# Altium schematic sheet STB_ValidationBoard.SchDoc
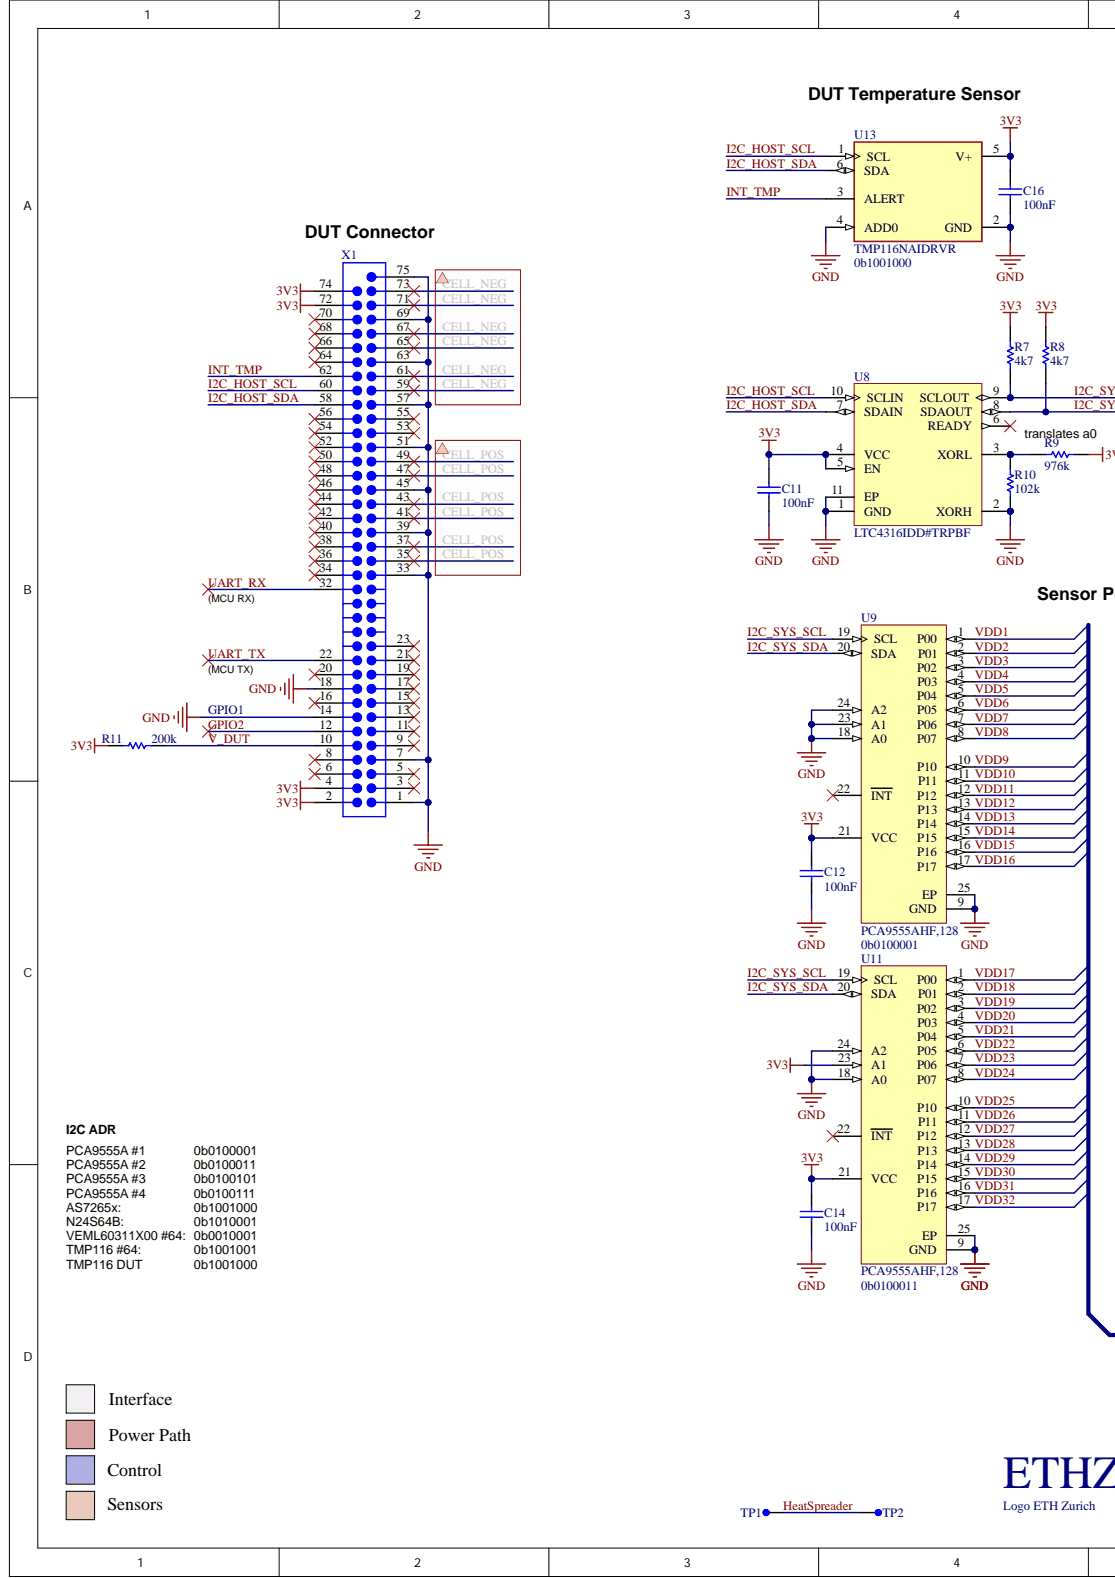
[diagram p0: STB_ValidationBoard.SchDoc, left]
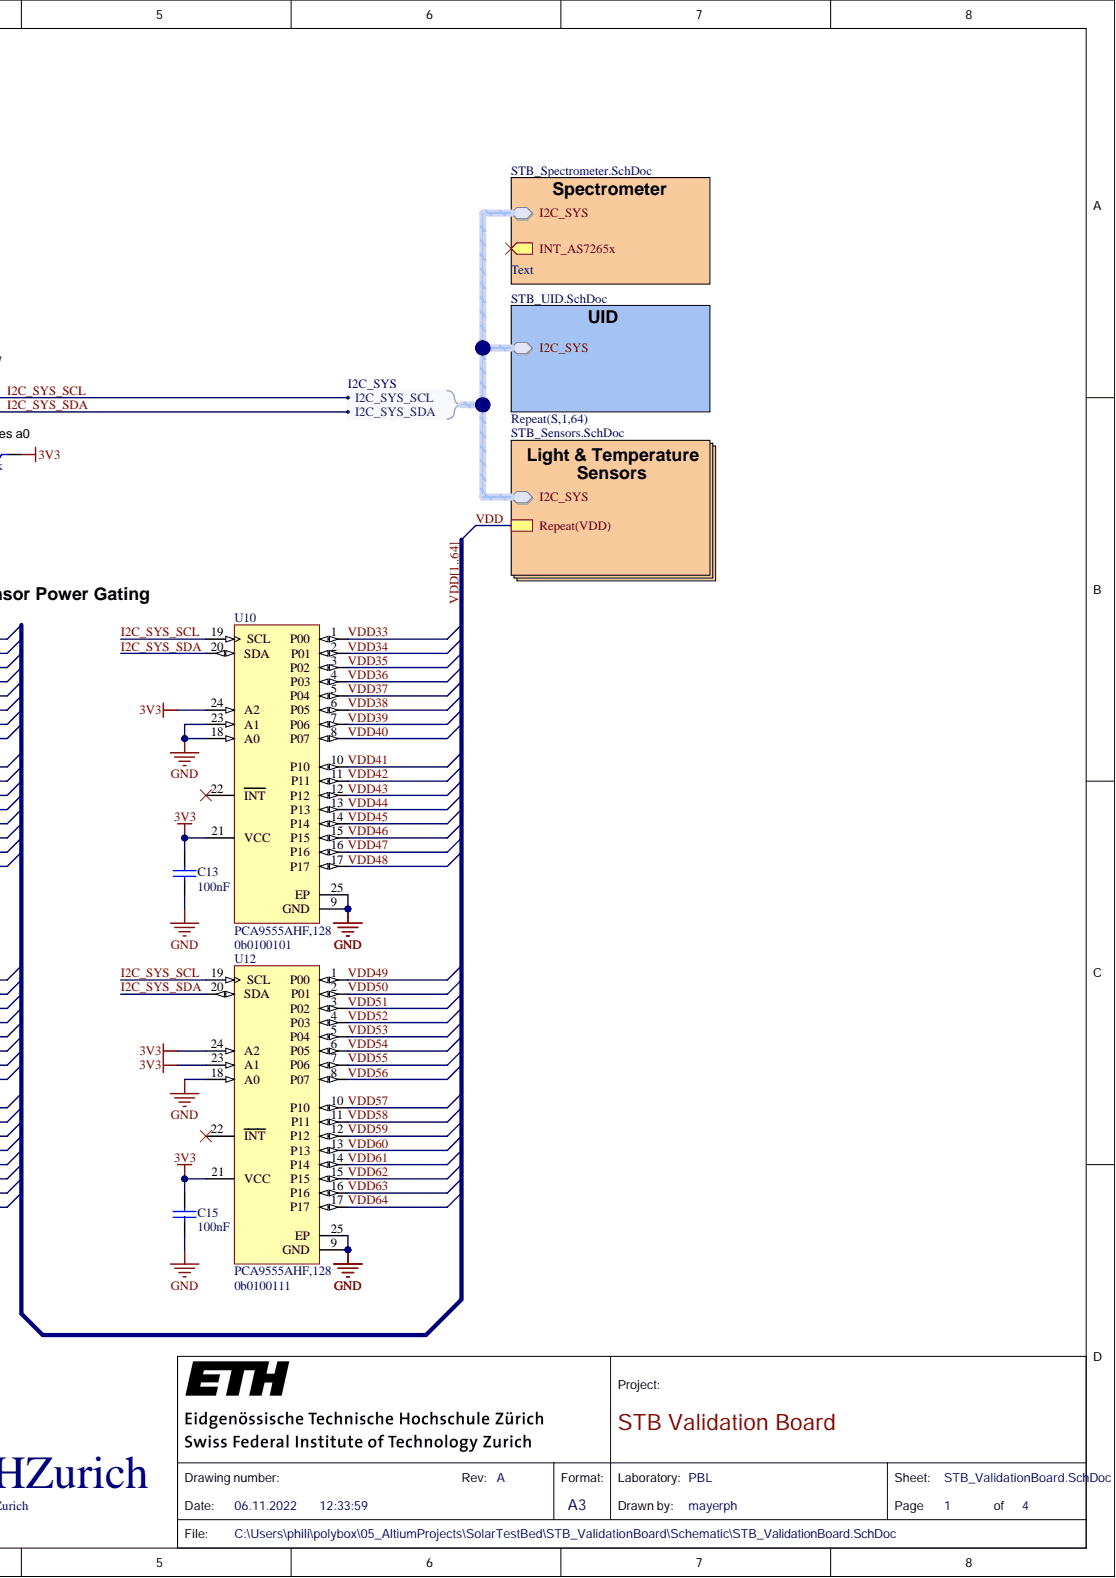
[diagram p1: STB_ValidationBoard.SchDoc, right]

## Parts
A1  Logo ETH Zurich  [eth-zurich_5mm]  (no BOM)
C11  100nF  [CAPC1050X55X23LL05T13]
C12  100nF  [CAPC1050X55X23LL05T13]
C13  100nF  [CAPC1050X55X23LL05T13]
C14  100nF  [CAPC1050X55X23LL05T13]
C15  100nF  [CAPC1050X55X23LL05T13]
C16  100nF  [CAPC1050X55X23LL05T13]
R7  4k7  [RESC1005X40X25LL05T10]
R8  4k7  [RESC1005X40X25LL05T10]
R9  976k  [RESC1005X40X25LL05T05]
R10  102k  [RESC1005X40X25LL05T05]
R11  200k  [RESC1005X40X25LL05T10]
TP1  testPoint_1mm  [TP-SM-C-100]  (value not drawn)  (no BOM)
TP2  testPoint_1mm  [TP-SM-C-100]  (value not drawn)  (no BOM)
U8  LTC4316IDD#TRPBF  [DFN10(3x3)_N]
U9  PCA9555AHF,128  [RGE0024C]
U10  PCA9555AHF,128  [RGE0024C]
U11  PCA9555AHF,128  [RGE0024C]
U12  PCA9555AHF,128  [RGE0024C]
U13  TMP116NAIDRVR  Address: 0b1001000  [FP-DRV0006B-MFG]
X1  CONN PWR CARD EDGE PCB SLOT MALE  [CON-67_0.5MM_PCB_SLOT]  (value not drawn)  (no BOM)

## Nets
3V3: C11.2 C12.2 C13.2 C14.2 C15.2 C16.2 R7.2 R8.2 R9.1 R11.2 U8.4(VCC) U8.5(EN) U9.21(VCC) U10.21(VCC) U10.24(A2) U11.21(VCC) U11.23(A1) U12.21(VCC) U12.23(A1) U12.24(A2) U13.5(V+) X1.2 X1.4 X1.72 X1.74
GND: C11.1 C12.1 C13.1 C14.1 C15.1 C16.1 R10.2 U8.1(GND) U8.2(XORH) U8.11(EP) U9.9(GND) U9.18(A0) U9.23(A1) U9.24(A2) U9.25(EP) U10.9(GND) U10.18(A0) U10.23(A1) U10.25(EP) U11.9(GND) U11.18(A0) U11.24(A2) U11.25(EP) U12.9(GND) U12.18(A0) U12.25(EP) U13.2(GND) U13.4(ADD0) X1.1 X1.7 X1.14 X1.18 X1.33 X1.39 X1.45 X1.51 X1.57 X1.63 X1.69 X1.75
GPIO2: X1.12
HeatSpreader: TP1.1 TP2.1
I2C_HOST_SCL: U8.10(SCLIN) U13.1(SCL) X1.60
I2C_HOST_SDA: U8.7(SDAIN) U13.6(SDA) X1.58
I2C_SYS_SCL: R7.1 U8.9(SCLOUT) U9.19(SCL) U10.19(SCL) U11.19(SCL) U12.19(SCL)
I2C_SYS_SDA: R8.1 U8.8(SDAOUT) U9.20(SDA) U10.20(SDA) U11.20(SDA) U12.20(SDA)
INT_TMP: U13.3(ALERT) X1.62
UART_RX: X1.32
UART_TX: X1.22
V_DUT: R11.1 X1.10
VDD1: U9.1(P00)  [also labelled VDD]
VDD2: U9.2(P01)  [also labelled VDD]
VDD3: U9.3(P02)  [also labelled VDD]
VDD4: U9.4(P03)  [also labelled VDD]
VDD5: U9.5(P04)  [also labelled VDD]
VDD6: U9.6(P05)  [also labelled VDD]
VDD7: U9.7(P06)  [also labelled VDD]
VDD8: U9.8(P07)  [also labelled VDD]
VDD9: U9.10(P10)  [also labelled VDD]
VDD10: U9.11(P11)  [also labelled VDD]
VDD11: U9.12(P12)  [also labelled VDD]
VDD12: U9.13(P13)  [also labelled VDD]
VDD13: U9.14(P14)  [also labelled VDD]
VDD14: U9.15(P15)  [also labelled VDD]
VDD15: U9.16(P16)  [also labelled VDD]
VDD16: U9.17(P17)  [also labelled VDD]
VDD17: U11.1(P00)  [also labelled VDD]
VDD18: U11.2(P01)  [also labelled VDD]
VDD19: U11.3(P02)  [also labelled VDD]
VDD20: U11.4(P03)  [also labelled VDD]
VDD21: U11.5(P04)  [also labelled VDD]
VDD22: U11.6(P05)  [also labelled VDD]
VDD23: U11.7(P06)  [also labelled VDD]
VDD24: U11.8(P07)  [also labelled VDD]
VDD25: U11.10(P10)  [also labelled VDD]
VDD26: U11.11(P11)  [also labelled VDD]
VDD27: U11.12(P12)  [also labelled VDD]
VDD28: U11.13(P13)  [also labelled VDD]
VDD29: U11.14(P14)  [also labelled VDD]
VDD30: U11.15(P15)  [also labelled VDD]
VDD31: U11.16(P16)  [also labelled VDD]
VDD32: U11.17(P17)  [also labelled VDD]
VDD33: U10.1(P00)  [also labelled VDD]
VDD34: U10.2(P01)  [also labelled VDD]
VDD35: U10.3(P02)  [also labelled VDD]
VDD36: U10.4(P03)  [also labelled VDD]
VDD37: U10.5(P04)  [also labelled VDD]
VDD38: U10.6(P05)  [also labelled VDD]
VDD39: U10.7(P06)  [also labelled VDD]
VDD40: U10.8(P07)  [also labelled VDD]
VDD41: U10.10(P10)  [also labelled VDD]
VDD42: U10.11(P11)  [also labelled VDD]
VDD43: U10.12(P12)  [also labelled VDD]
VDD44: U10.13(P13)  [also labelled VDD]
VDD45: U10.14(P14)  [also labelled VDD]
VDD46: U10.15(P15)  [also labelled VDD]
VDD47: U10.16(P16)  [also labelled VDD]
VDD48: U10.17(P17)  [also labelled VDD]
VDD49: U12.1(P00)  [also labelled VDD]
VDD50: U12.2(P01)  [also labelled VDD]
VDD51: U12.3(P02)  [also labelled VDD]
VDD52: U12.4(P03)  [also labelled VDD]
VDD53: U12.5(P04)  [also labelled VDD]
VDD54: U12.6(P05)  [also labelled VDD]
VDD55: U12.7(P06)  [also labelled VDD]
VDD56: U12.8(P07)  [also labelled VDD]
VDD57: U12.10(P10)  [also labelled VDD]
VDD58: U12.11(P11)  [also labelled VDD]
VDD59: U12.12(P12)  [also labelled VDD]
VDD60: U12.13(P13)  [also labelled VDD]
VDD61: U12.14(P14)  [also labelled VDD]
VDD62: U12.15(P15)  [also labelled VDD]
VDD63: U12.16(P16)  [also labelled VDD]
VDD64: U12.17(P17)  [also labelled VDD]
NetR9_2: R9.2 R10.1 U8.3(XORL)
NetU8_6: U8.6(READY)
NetU9_22: U9.22(INT)
NetU10_22: U10.22(INT)
NetU11_22: U11.22(INT)
NetU12_22: U12.22(INT)
NetX1_3: X1.3
NetX1_5: X1.5
NetX1_6: X1.6
NetX1_8: X1.8
NetX1_9: X1.9
NetX1_11: X1.11
NetX1_13: X1.13
NetX1_15: X1.15
NetX1_16: X1.16
NetX1_17: X1.17
NetX1_19: X1.19
NetX1_20: X1.20
NetX1_21: X1.21
NetX1_23: X1.23
NetX1_34: X1.34
NetX1_35: X1.35
NetX1_36: X1.36
NetX1_37: X1.37
NetX1_38: X1.38
NetX1_40: X1.40
NetX1_41: X1.41
NetX1_42: X1.42
NetX1_43: X1.43
NetX1_44: X1.44
NetX1_46: X1.46
NetX1_47: X1.47
NetX1_48: X1.48
NetX1_49: X1.49
NetX1_50: X1.50
NetX1_52: X1.52
NetX1_53: X1.53
NetX1_54: X1.54
NetX1_55: X1.55
NetX1_56: X1.56
NetX1_59: X1.59
NetX1_61: X1.61
NetX1_64: X1.64
NetX1_65: X1.65
NetX1_66: X1.66
NetX1_67: X1.67
NetX1_68: X1.68
NetX1_70: X1.70
NetX1_71: X1.71
NetX1_73: X1.73
INT_AS7265x:

## Library data of the parts
A1 = CMP-00038-1: Library Name: ETHZ Vault
C11-C16 = CMP-2000-05439-1: Chip Capacitor, 100 nF, +/- 10%, 16 V, -55 to 125 degC, 0402 (1005 Metric), RoHS, Tape and Reel; Manufacturer: Samsung Electro-Mechanics; Manufacturer Part Number: CL05B104KO5NNNC; Capacitor Type: Ceramic; Dielectric: X7R; Multilayer: Yes; Rated DC Voltage (URdc): 16V; Case Size/Dimension: 0402; Category: Ceramic Capacitors; Component Kind: Standard; ComponentLink1Description: https://datasheet.ciiva.com:443/pdfs/VipMasterIC/IC/SEMS/SEMS-S-A0008384515/SEMS-S-A0008872921-1.pdf?src-supplier=IHS+Markit; ComponentLink1URL: https://datasheet.ciiva.com:443/pdfs/VipMasterIC/IC/SEMS/SEMS-S-A0008384515/SEMS-S-A0008872921-1.pdf?src-supplier=IHS+Markit; ComponentLink2Description: https://datasheet.ciiva.com/26920/getdatasheetpartid-359683-26920169.pdf?src-supplier=Verical; ComponentLink2URL: https://datasheet.ciiva.com/26920/getdatasheetpartid-359683-26920169.pdf?src-supplier=Verical; ComponentLink3Description: https://datasheet.ciiva.com/29198/458718-da-01-en-vs-kondensator-0402-29198423.pdf?src-supplier=Conrad; ComponentLink3URL: https://datasheet.ciiva.com/29198/458718-da-01-en-vs-kondensator-0402-29198423.pdf?src-supplier=Conrad; ComponentLink4Description: https://datasheet.ciiva.com/26309/mlcc-catalogdownload2013-eng-26309003.pdf?src-supplier=Distrelec; ComponentLink4URL: https://datasheet.ciiva.com/26309/mlcc-catalogdownload2013-eng-26309003.pdf?src-supplier=Distrelec; ComponentLink5Description: https://datasheet.ciiva.com/16208/cl05b104kq5nnnc-16208343.pdf?src-supplier=Future+Electronics; ComponentLink5URL: https://datasheet.ciiva.com/16208/cl05b104kq5nnnc-16208343.pdf?src-supplier=Future+Electronics; Datasheet URL: https://datasheet.ciiva.com/29198/458718-da-01-en-vs-kondensator-0402-29198423.pdf; Datasheet Version: Nov-10; Dielectric Material: Ceramic; Height: 0.55mm; JESD-609 Code: e3; Length: 1mm; Library Name: Altium Content Vault; Manufacturer URL: http://www.samsungsem.com/global/index.jsp; Max Operating Temperature: 125°C; Min Operating Temperature: -55°C; Mount: Surface Mount; Negative Tolerance: 10%; Number of Pins: 2; Package Shape: Rectangular; Package Style: SMTMeter; Packaging: Tape and Reel; Positive Tolerance: 10%; Pricing 1: 1=0.10000, 10=0.02400, 50=0.01300, 100=0.01050, 500=0.00752, 1000=0.00592, 2500=0.00538, 5000=0.00495 (USD); Rated Voltage: 16V; REACH SVHC: Yes; RoHS: Yes; RoHS Compliant: Yes; Size Code: 0402; Stock 1: 24854634; Supplier 1: Digi-Key; Supplier Part Number 1: 1276-1001-6-ND; Temperature Characteristics Code: X7R; Terminal Finish: Nickel; Termination: Wraparound; Tolerance (%): ±10%; Value: 100nF; Width: 0.5mm
R7 R8 = CMP-1011-00586-2: Manufacturer: Yageo / Phycomp; Manufacturer Part Number: RC0402FR-074K7L; Additional Feature: LASER TRIMMABLE; Package Height: 0.35mm; Package Length: 1mm; Package Width: 0.5mm; Rated Power Dissipation (P): 62.5mW; Rated Temperature: 70°C; Temperature Coefficient: 100ppm/°C; Tolerance: 1%; Value: 4.7 kOhm; Working Voltage: 50V; Category: Chip SMD Resistors; Component Kind: Standard; ComponentLink1Description: https://datasheet.ciiva.com:443/pdfs/VipMasterIC/IC/YAGO/YAGO-S-A0008145938/YAGO-S-A0008145938-1.pdf?src-supplier=IHS+Markit; ComponentLink1URL: https://datasheet.ciiva.com:443/pdfs/VipMasterIC/IC/YAGO/YAGO-S-A0008145938/YAGO-S-A0008145938-1.pdf?src-supplier=IHS+Markit; ComponentLink2Description: https://datasheet.ciiva.com/22661/1686128-22661342.pdf?src-supplier=Element14; ComponentLink2URL: https://datasheet.ciiva.com/22661/1686128-22661342.pdf?src-supplier=Element14; ComponentLink3Description: https://datasheet.ciiva.com/7850/1018594-7850022.pdf?src-supplier=Element14; ComponentLink3URL: https://datasheet.ciiva.com/7850/1018594-7850022.pdf?src-supplier=Element14; ComponentLink4Description: https://datasheet.ciiva.com/7850/1018594-7850023.pdf?src-supplier=Element14; ComponentLink4URL: https://datasheet.ciiva.com/7850/1018594-7850023.pdf?src-supplier=Element14; ComponentLink5Description: https://datasheet.ciiva.com/15215/getdatasheetpartid-328119-15215035.pdf?src-supplier=Verical; ComponentLink5URL: https://datasheet.ciiva.com/15215/getdatasheetpartid-328119-15215035.pdf?src-supplier=Verical; Construction: Chip; JESD-609 Code: e3; Library Name: Altium Content Vault; Max Operating Temperature: 155°C; Min Operating Temperature: -55°C; Mount: Surface Mount; Number of Pins: 2; Package Shape: Rectangular; Package Style: SMTMeter; Packaging: Tape and Reel; Part Number: RC0402FR-074K7L; Pricing 1: 1=0.10000, 10=0.01500, 100=0.00600, 1000=0.00267, 2500=0.00232, 5000=0.00192 (USD); REACH SVHC: Yes; Resistor Type: FIXED RESISTOR; RoHS: Yes; RoHS Compliant: Yes; Size Code: 0402; Stock 1: 23638739; Supplier 1: Digi-Key; Supplier Part Number 1: 311-4.7KLRDKR-ND; Technology: METAL GLAZE/THICK FILM; Terminal Finish: Nickel; Termination: Wraparound
R9 = CMP-2002-01120-1: Manufacturer: Panasonic; Manufacturer Part Number: ERJ-2RKF9763X; Package Height: 0.35mm; Package Length: 1mm; Package Width: 0.5mm; Rated Power Dissipation (P): 100mW; Rated Temperature: 70°C; Reference Standard: AEC-Q200; Temperature Coefficient: 100ppm/°C; Tolerance: 1%; Value: 976 kOhm; Working Voltage: 50V; Category: Chip SMD Resistors; Component Kind: Standard; ComponentLink1Description: https://datasheet.ciiva.com:443/pdfs/VipMasterIC/IC/PANA/PANA-S-A0009889626/PANA-S-A0009889626-1.pdf?src-supplier=IHS+Markit; ComponentLink1URL: https://datasheet.ciiva.com:443/pdfs/VipMasterIC/IC/PANA/PANA-S-A0009889626/PANA-S-A0009889626-1.pdf?src-supplier=IHS+Markit; ComponentLink2Description: https://datasheet.ciiva.com/43467/1678782-43467581.pdf?src-supplier=Farnell; ComponentLink2URL: https://datasheet.ciiva.com/43467/1678782-43467581.pdf?src-supplier=Farnell; ComponentLink3Description: https://datasheet.ciiva.com/46372/1678782-46372911.pdf?src-supplier=Element14; ComponentLink3URL: https://datasheet.ciiva.com/46372/1678782-46372911.pdf?src-supplier=Element14; ComponentLink4Description: https://datasheet.ciiva.com/22668/1678782-22668415.pdf?src-supplier=Element14; ComponentLink4URL: https://datasheet.ciiva.com/22668/1678782-22668415.pdf?src-supplier=Element14; ComponentLink5Description: https://datasheet.ciiva.com/16758/70260748-16758903.pdf?src-supplier=Allied+Electronics; ComponentLink5URL: https://datasheet.ciiva.com/16758/70260748-16758903.pdf?src-supplier=Allied+Electronics; ComponentLink6Description: https://datasheet.ciiva.com/9179/aoa0000ce2-9179357.pdf?src-supplier=Avnet; ComponentLink6URL: https://datasheet.ciiva.com/9179/aoa0000ce2-9179357.pdf?src-supplier=Avnet; ComponentLink7Description: https://datasheet.ciiva.com/30176/aoa0000ce2-30176831.pdf?src-supplier=Onlinecomponents.com; ComponentLink7URL: https://datasheet.ciiva.com/30176/aoa0000ce2-30176831.pdf?src-supplier=Onlinecomponents.com; Construction: Rectangular; JESD-609 Code: e3; Library Name: Altium Content Vault; Max Operating Temperature: 155°C; Min Operating Temperature: -55°C; Mount: Surface Mount; Number of Pins: 2; Package Shape: Rectangular; Package Style: SMTMeter; Packaging: Tape and Reel; Part Number: ERJ-2RKF9763X; Pricing 1: 1=0.10000, 10=0.03300, 100=0.01330, 1000=0.00599, 2500=0.00520, 5000=0.00429 (USD); REACH SVHC: Yes; Resistor Type: FIXED RESISTOR; RoHS: Yes; RoHS Compliant: Yes; Size Code: 0402; Stock 1: 136638; Supplier 1: Digi-Key; Supplier Part Number 1: P976KLDKR-ND; Technology: METAL GLAZE/THICK FILM; Terminal Finish: Nickel; Termination: Wraparound
R10 = CMP-2002-00613-1: Manufacturer: Panasonic; Manufacturer Part Number: ERJ-2RKF1023X; Package Height: 0.35mm; Package Length: 1mm; Package Width: 0.5mm; Rated Power Dissipation (P): 100mW; Rated Temperature: 70°C; Reference Standard: AEC-Q200; Temperature Coefficient: 100ppm/°C; Tolerance: 1%; Value: 102 kOhm; Working Voltage: 50V; Category: Chip SMD Resistors; Component Kind: Standard; ComponentLink1Description: https://datasheet.ciiva.com:443/pdfs/VipMasterIC/IC/PANA/PANA-S-A0009889626/PANA-S-A0009889626-1.pdf?src-supplier=IHS+Markit; ComponentLink1URL: https://datasheet.ciiva.com:443/pdfs/VipMasterIC/IC/PANA/PANA-S-A0009889626/PANA-S-A0009889626-1.pdf?src-supplier=IHS+Markit; ComponentLink2Description: https://datasheet.ciiva.com/43467/1678782-43467481.pdf?src-supplier=Farnell; ComponentLink2URL: https://datasheet.ciiva.com/43467/1678782-43467481.pdf?src-supplier=Farnell; ComponentLink3Description: https://datasheet.ciiva.com/9119/aoa0000ce2-9119242.pdf?src-supplier=Avnet; ComponentLink3URL: https://datasheet.ciiva.com/9119/aoa0000ce2-9119242.pdf?src-supplier=Avnet; ComponentLink4Description: https://datasheet.ciiva.com/16781/70260748-16781503.pdf?src-supplier=Allied+Electronics; ComponentLink4URL: https://datasheet.ciiva.com/16781/70260748-16781503.pdf?src-supplier=Allied+Electronics; ComponentLink5Description: https://datasheet.ciiva.com/22673/1678782-22673487.pdf?src-supplier=Element14; ComponentLink5URL: https://datasheet.ciiva.com/22673/1678782-22673487.pdf?src-supplier=Element14; ComponentLink6Description: https://datasheet.ciiva.com/16763/70260748-16763080.pdf?src-supplier=Allied+Electronics; ComponentLink6URL: https://datasheet.ciiva.com/16763/70260748-16763080.pdf?src-supplier=Allied+Electronics; ComponentLink7Description: https://datasheet.ciiva.com/7712/1678782-7712798.pdf?src-supplier=Element14; ComponentLink7URL: https://datasheet.ciiva.com/7712/1678782-7712798.pdf?src-supplier=Element14; ComponentLink8Description: https://datasheet.ciiva.com/46373/1678782-46373215.pdf?src-supplier=Element14; ComponentLink8URL: https://datasheet.ciiva.com/46373/1678782-46373215.pdf?src-supplier=Element14; Construction: Rectangular; JESD-609 Code: e3; Library Name: Altium Content Vault; Max Operating Temperature: 155°C; Min Operating Temperature: -55°C; Mount: Surface Mount; Number of Pins: 2; Package Shape: Rectangular; Package Style: SMTMeter; Packaging: Tape and Reel; Part Number: ERJ-2RKF1023X; Pricing 1: 1=0.10000, 10=0.03300, 100=0.01330, 1000=0.00599, 2500=0.00520, 5000=0.00429 (USD); Reach SVHC Compliant: Yes; Resistor Type: FIXED RESISTOR; RoHS: Yes; RoHS Compliant: Yes; Size Code: 0402; Stock 1: 79400; Supplier 1: Digi-Key; Supplier Part Number 1: P102KLDKR-ND; Technology: METAL GLAZE/THICK FILM; Terminal Finish: Nickel; Termination: Wraparound
R11 = CMP-2002-07497-1: Manufacturer: Yageo / Phycomp; Manufacturer Part Number: RC0402FR-07200KL; Additional Feature: LASER TRIMMABLE; Package Height: 0.35mm; Package Length: 1mm; Package Width: 0.5mm; Rated Power Dissipation (P): 62.5mW; Rated Temperature: 70°C; Temperature Coefficient: 100ppm/°C; Tolerance: 1%; Value: 200 kOhm; Working Voltage: 50V; Category: Chip SMD Resistors; Component Kind: Standard; ComponentLink1Description: https://datasheet.ciiva.com:443/pdfs/VipMasterIC/IC/YAGO/YAGO-S-A0008145938/YAGO-S-A0008145938-1.pdf?src-supplier=IHS+Markit; ComponentLink1URL: https://datasheet.ciiva.com:443/pdfs/VipMasterIC/IC/YAGO/YAGO-S-A0008145938/YAGO-S-A0008145938-1.pdf?src-supplier=IHS+Markit; ComponentLink2Description: https://datasheet.ciiva.com/7849/1018594-7849897.pdf?src-supplier=Element14; ComponentLink2URL: https://datasheet.ciiva.com/7849/1018594-7849897.pdf?src-supplier=Element14; ComponentLink3Description: https://datasheet.ciiva.com/7849/1018594-7849896.pdf?src-supplier=Element14; ComponentLink3URL: https://datasheet.ciiva.com/7849/1018594-7849896.pdf?src-supplier=Element14; ComponentLink4Description: https://datasheet.ciiva.com/46394/1018594-46394953.pdf?src-supplier=Element14; ComponentLink4URL: https://datasheet.ciiva.com/46394/1018594-46394953.pdf?src-supplier=Element14; ComponentLink5Description: https://datasheet.ciiva.com/2453/pyu-r-int-thick-7-2453557.pdf?src-supplier=Digi-Key; ComponentLink5URL: https://datasheet.ciiva.com/2453/pyu-r-int-thick-7-2453557.pdf?src-supplier=Digi-Key; ComponentLink6Description: https://datasheet.ciiva.com/2453/pyu-r-int-thick-7-2453558.pdf?src-supplier=Digi-Key; ComponentLink6URL: https://datasheet.ciiva.com/2453/pyu-r-int-thick-7-2453558.pdf?src-supplier=Digi-Key; ComponentLink7Description: https://datasheet.ciiva.com/2453/pyu-r-int-thick-7-2453556.pdf?src-supplier=Digi-Key; ComponentLink7URL: https://datasheet.ciiva.com/2453/pyu-r-int-thick-7-2453556.pdf?src-supplier=Digi-Key; ComponentLink8Description: https://datasheet.ciiva.com/12667/pyu-rc0402-51-rohs-l-6-r-12667911.pdf?src-supplier=Mouser; ComponentLink8URL: https://datasheet.ciiva.com/12667/pyu-rc0402-51-rohs-l-6-r-12667911.pdf?src-supplier=Mouser; ComponentLink9Description: https://datasheet.ciiva.com/15189/getdatasheetpartid-323720-15189381.pdf?src-supplier=Verical; ComponentLink9URL: https://datasheet.ciiva.com/15189/getdatasheetpartid-323720-15189381.pdf?src-supplier=Verical; ComponentLink10Description: https://datasheet.ciiva.com/16740/pyu-rc0402-51-rohs-l-6-r-16740636.pdf?src-supplier=Future+Electronics; ComponentLink10URL: https://datasheet.ciiva.com/16740/pyu-rc0402-51-rohs-l-6-r-16740636.pdf?src-supplier=Future+Electronics; Construction: Chip; JESD-609 Code: e3; Library Name: Altium Content Vault; Max Operating Temperature: 155°C; Min Operating Temperature: -55°C; Mount: Surface Mount; Number of Pins: 2; Package Shape: Rectangular; Package Style: SMTMeter; Packaging: Tape and Reel; Part Number: RC0402FR-07200KL; Pricing 1: 1=0.10000, 10=0.01500, 100=0.00600, 1000=0.00267, 2500=0.00232, 5000=0.00192 (USD); REACH SVHC: Yes; Resistor Type: FIXED RESISTOR; RoHS: Yes; RoHS Compliant: Yes; Size Code: 0402; Stock 1: 2033311; Supplier 1: Digi-Key; Supplier Part Number 1: 311-200KLRDKR-ND; Technology: METAL GLAZE/THICK FILM; Terminal Finish: Nickel; Termination: Wraparound
TP1 TP2 = testPoint_1mm: testPoint 1mm; Library Name: STB_Schlib.SchLib
U8 = CMP-02068-1: Single I2C/SMBus Address Translator; Manufacturer: Analog Devices / Linear Technology; Interface IC Type: INTERFACE CIRCUIT; Max Supply Voltage: 5.5V; Min Supply Voltage: 2.25V; Number of Functions: 1; Package Body Material: Plastic; Supply Voltage-Nom: 3.3V; Category: Interface ICs; Component Kind: Standard; ComponentLink1Description: https://datasheet.ciiva.com:443/pdfs/VipMasterIC/IC/LITC/LITC-S-A0001226702/LITC-S-A0001226702-1.pdf?src-supplier=IHS+Markit; ComponentLink1URL: https://datasheet.ciiva.com:443/pdfs/VipMasterIC/IC/LITC/LITC-S-A0001226702/LITC-S-A0001226702-1.pdf?src-supplier=IHS+Markit; ComponentLink2Description: http://www.linear.com/docs/46527?src-supplier=Digi-Key; ComponentLink2URL: http://www.linear.com/docs/46527?src-supplier=Digi-Key; Height - Seated (Max): 0.8mm; JESD-30 Code: S-PDSO-N10; Length: 3mm; Library Name: ETHZ Vault; Manufacturer Part Number: LTC4316IDD#TRPBF; Max Operating Temperature: 85°C; Min Operating Temperature: -40°C; Number of Pins: 10; Package Code: VSON; Package Shape: Square; Package Style: SMALL OUTLINE, VERY THIN PROFILEMeter; Pricing 1: 1=5.70000, 10=5.12100, 25=4.84160, 100=4.19600, 250=3.98084, 500=3.57198, 1000=3.04500 (USD); REACH SVHC: Yes; RoHS: Yes; RoHS Compliant: Yes; Stock 1: 531; Supplier 1: Digi-Key; Supplier Part Number 1: 505-LTC4316IDD#TRPBFDKR-ND; Surface Mount: Yes; Technology: CMOS; Temperature Grade: INDUSTRIAL; Terminal Form: No Lead; Terminal Pitch: 0.5mm; Terminal Position: DUAL; Width: 3mm
U9-U12 = CMP-0314-00043-2: Manufacturer: NXP Semiconductors; Max Supply Voltage: 5.5V; Min Supply Voltage: 1.65V; Number of Bits: 16; Number of Ports: 2; Package Body Material: Plastic; Package Equivalence Code: LCC24,.16SQ,20; Power Supplies: 1.8/5V; Qualification Status: Not Qualified; Supply Voltage-Nom: 1.8V; Category: Interface ICs; Component Kind: Standard; ComponentLink1Description: https://datasheet.ciiva.com:443/pdfs/VipMasterIC/IC/PHGL/PHGL-S-A0001284358/PHGL-S-A0001284358-1.pdf?src-supplier=IHS+Markit; ComponentLink1URL: https://datasheet.ciiva.com:443/pdfs/VipMasterIC/IC/PHGL/PHGL-S-A0001284358/PHGL-S-A0001284358-1.pdf?src-supplier=IHS+Markit; ComponentLink2Description: https://datasheet.ciiva.com/12072/pca9555a-211666-12072629.pdf?src-supplier=Mouser; ComponentLink2URL: https://datasheet.ciiva.com/12072/pca9555a-211666-12072629.pdf?src-supplier=Mouser; ComponentLink3Description: https://datasheet.ciiva.com/6633/pca9555a-6633081.pdf?src-supplier=Digi-Key; ComponentLink3URL: https://datasheet.ciiva.com/6633/pca9555a-6633081.pdf?src-supplier=Digi-Key; ComponentLink4Description: https://datasheet.ciiva.com/6633/design-con-2003-tecforum-i2c-bus-overview-6633083.pdf?src-supplier=Digi-Key; ComponentLink4URL: https://datasheet.ciiva.com/6633/design-con-2003-tecforum-i2c-bus-overview-6633083.pdf?src-supplier=Digi-Key; ComponentLink5Description: https://datasheet.ciiva.com/6633/design-con-2003-tecforum-i2c-bus-overview-6633082.pdf?src-supplier=Digi-Key; ComponentLink5URL: https://datasheet.ciiva.com/6633/design-con-2003-tecforum-i2c-bus-overview-6633082.pdf?src-supplier=Digi-Key; ComponentLink6Description: https://datasheet.ciiva.com/46433/1845292-46433837.pdf?src-supplier=Element14; ComponentLink6URL: https://datasheet.ciiva.com/46433/1845292-46433837.pdf?src-supplier=Element14; Height - Seated (Max): 0.8mm; Length: 4mm; Library Name: Altium Content Vault; Manufacturer Part Number: PCA9555AHF,128; Max Operating Temperature: 85°C; Min Operating Temperature: -40°C; Moisture Sensitivity Level: 1; Number of I/O Lines: 16; Number of Pins: 24; Package Code: VQCCN; Package Shape: Square; Package Style: CHIP CARRIER, VERY THIN PROFILEMeter; Pricing 1: 1=2.47000, 10=2.22300, 25=2.09680, 100=1.78650, 250=1.67748, 500=1.46780, 1000=1.32601 (USD); REACH SVHC: Yes; RoHS: Yes; RoHS Compliant: Yes; Stock 1: 565; Supplier 1: Digi-Key; Supplier Part Number 1: 568-9942-1-ND; Surface Mount: Yes; Technology: CMOS; Temperature Grade: INDUSTRIAL; Terminal Form: No Lead; Terminal Pitch: 0.5mm; Terminal Position: QUAD; Width: 4mm
U13 = CMP-04948-000013-1: SENSOR DIGITAL -55C-125C 6WSON; Manufacturer: Texas Instruments; Accuracy-Max (Cel): 0.5°C; Body Breadth: 2inch; Body Height: 0.8mm; Body Length or Diameter: 2mm; Interface: I2C,SMBus; Max Junction Temp: +150°C; Max Supply Voltage: 5.5V; Min Supply Voltage: 1.9V; Number of Bits: 16; Operating Supply Current: 3.5-135uA; Output Interface Type: 2-Wire; Package Shape/Style: Square; Resolution: 16bits; Sensors/Transducers Type: TEMPERATURE SENSOR,SWITCH/DIGITAL OUTPUT,SERIAL; Standoff Height: 0mm; Ambient Temperature Range High: +150°C; Ambient Temperature Range Low: -55°C; Automotive: No; Category: IC; Component Kind: Standard; ComponentLink1Description: https://www.ti.com:443/lit/ds/symlink/tmp116.pdf?src-supplier=IHS+Markit; ComponentLink1URL: https://www.ti.com:443/lit/ds/symlink/tmp116.pdf?src-supplier=IHS+Markit; ComponentLink2Description: Datasheet; ComponentLink2URL: https://www.ti.com/lit/ds/symlink/tmp116.pdf; ComponentLink3Description: http://www.ti.com/lit/ds/symlink/tmp116.pdf?src-supplier=Digi-Key; ComponentLink3URL: http://www.ti.com/lit/ds/symlink/tmp116.pdf?src-supplier=Digi-Key; Device Class L1: Sensors; Device Class L2: Temperature and Humidity Sensors; Device Class L3: unset; Digikey Description: SENSOR DIGITAL -55C-125C 6WSON; Height: 0.8mm; Housing Material: Plastic; Ipc Land Pattern Name: DFN200X200X80-6; JESD-609 Code: e4; Lead Free: Yes; Library Name: Altium Content Vault; Manufacturer Part Number: TMP116NAIDRVR; Max Operating Temperature: 150°C; Min Operating Temperature: -55°C; Mount: Surface Mount; Mouser Description: ±0.2°C Accurate Digital Temperature Sensor With NV Memory 6-WSON -55 to 125; Octopart: https://octopart.com/tmp116naidrvr-texas+instruments-82367758; Package: DRV0006B; Pricing 1: 1=2.48000, 10=2.22500, 25=2.09880, 100=1.78820, 250=1.67904, 500=1.46916, 1000=1.26720 (USD); REACH SVHC: Yes; RoHS: TRUE; RoHS Compliant: Yes; Sensor Output: I2C,SMBus; Stock 1: 6627; Supplier 1: Digi-Key; Supplier Part Number 1: 296-48306-6-ND; Temperature: -55°C to +125°C; Temperature Range High: +125°C; Temperature Range Low: -55°C; Terminal Finish: Nickel; Termination: Solder
X1 = CMP-01224-1: Mates with 2199119-4 - No BOM; Card Thickness: 0.8mm; Card Type: Non Specified - Dual Edge; Number of Positions: 67; Pitch: 0.5mm; Gender: Male; Library Name: ETHZ Vault; Mates with: 2199119-4
